# Altium schematic sheet Sheet3.SchDoc(Sheet3)
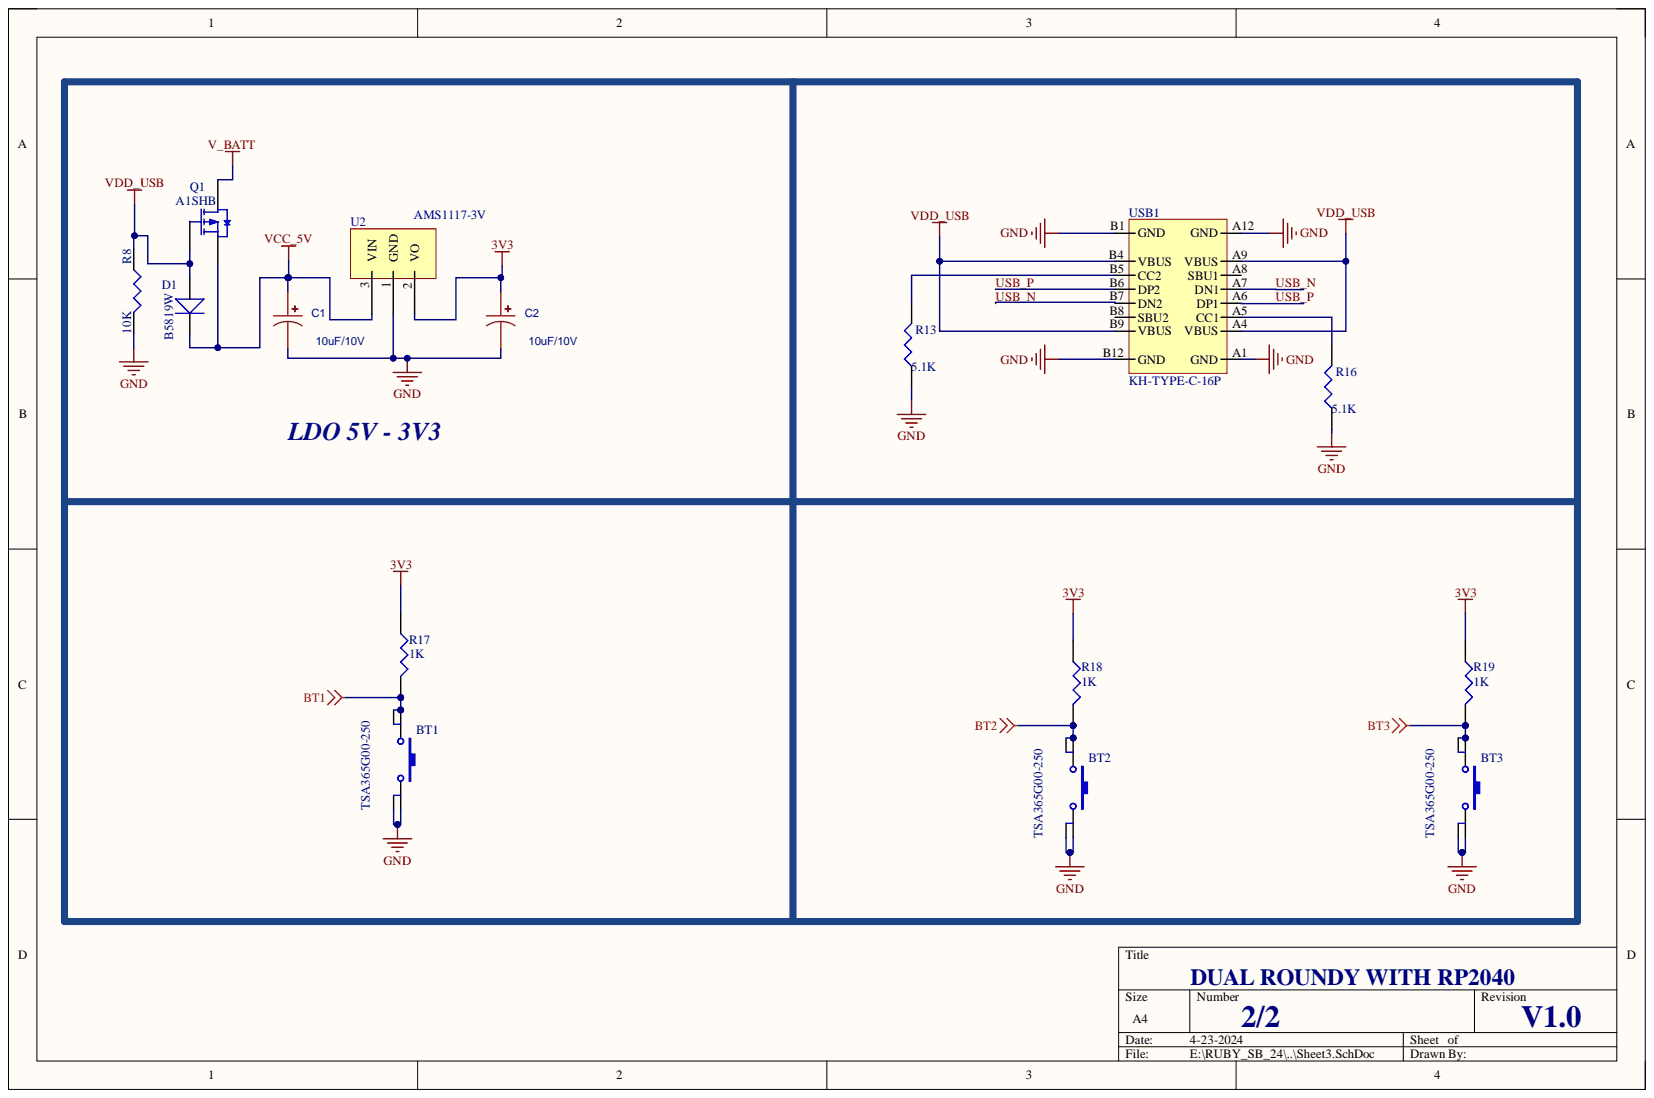
[diagram p0: Sheet3.SchDoc]

## Parts
BT1  TSA365G00-250  [TACT_]
BT2  TSA365G00-250  [TACT_]
BT3  TSA365G00-250  [TACT_]
C1  10uF/10V  [C_0603]
C2  10uF/10V  [C_0603]
D1  B5819W  [SOD-123]
Q1  A1SHB  [BSS84]
R8  10K  [R_0603]
R13  5.1K  [R_0603]
R16  5.1K  [R_0603]
R17  1K  [R_0603]
R18  1K  [R_0603]
R19  1K  [R_0603]
U2  AMS1117-3V  [AMS1117_3V3]
USB1  KH-TYPE-C-16P  [USB TYPE _C]

## Nets
3V3: C2.1 R17.1 R18.1 R19.1 U2.2
BT1: BT1.1 BT1.2 R17.2
BT2: BT2.1 BT2.2 R18.2
BT3: BT3.1 BT3.2 R19.2
GND: BT1.3 BT1.4 BT2.3 BT2.4 BT3.3 BT3.4 C1.2 C2.2 R8.2 R13.1 R16.1 U2.1 USB1.A1(GND) USB1.A12(GND) USB1.B1(GND) USB1.B12(GND)
V_BATT: Q1.3
VCC_5V: C1.1 D1.K Q1.2 U2.3
VDD_USB: D1.A Q1.1 R8.1 USB1.A4(VBUS) USB1.A9(VBUS) USB1.B4(VBUS) USB1.B9(VBUS)
USB_N: USB1.A7(DN1) USB1.B7(DN2)
USB_P: USB1.A6(DP1) USB1.B6(DP2)
NetR13_2: R13.2 USB1.B5(CC2)
NetR16_2: R16.2 USB1.A5(CC1)

Not connected: USB1 A8(SBU1) B8(SBU2)

## Library data of the parts
BT1-BT3 = TACTILE_SWITCH: Component Kind: Standard; Library Name: dxp_pasl_lib.IntLib
C1 C2 = 270uF: BOM_Manufacturer: rubycon(红宝石); BOM_Manufacturer Part: 100ZL270MEFC12.5X35; Contributor: lcsc; nameAlias: Capacitance; BOM_JLCPCB Part Class: Extended Part; BOM_Supplier: LCSC; BOM_Supplier Part: C1598036; Component Kind: Standard; link: https://atta.szlcsc.com/upload/public/pdf/source/20210810/D32E969E718DCFB364F518B2A745C406.pdf; spicePre: C; spiceSymbolName: 100ZL270MEFC12.5X35; Library Name: MIX.SchLib
D1 = DIODE: Component Kind: Standard; Library Name: dxp_pasl_lib.IntLib
Q1 = BSS84: 50V 130mA 250mW 10Ω 10V,130mA 2V 1mA P Channel SOT-23(SOT-23-3) MOSFETs ROHS #C493579; PackageDocument: 1995/1996; CATEGORY: MOSFET; Component Kind: Standard; LatestRevisionDate: 17-Jul-2002; LatestRevisionNote: Re-released for DXP Platform.; PackageReference: E3; Published: 8-Jun-2000; Library Name: dxp_pasl_lib.IntLib; Publisher: Altium Limited
R8 R13 R16-R19 = RES: Component Kind: Standard; Library Name: dxp_pasl_lib.IntLib
U2 = AMS1117: Component Kind: Standard; Library Name: dxp_pasl_lib.IntLib
USB1 = USB TYPE-C KH-TYPE-C-16P: 3A 1 Surface Mount 16 Female -40?~+85? Type-C SMD USB Connectors ROHS #  C709357; Component Kind: Standard; ComponentLink2Description: New link; ComponentLink2URL: https://lcsc.com/product-detail/USB-Connectors_Shenzhen-Kinghelm-Elec-KH-TYPE-C-16P_C709357.html; Supplier 1: LCSC; Supplier Part Number 1: C165948; Library Name: dxp_pasl_lib.IntLib
